# Altium schematic sheet Relay P4.SchDoc("Relay P4")
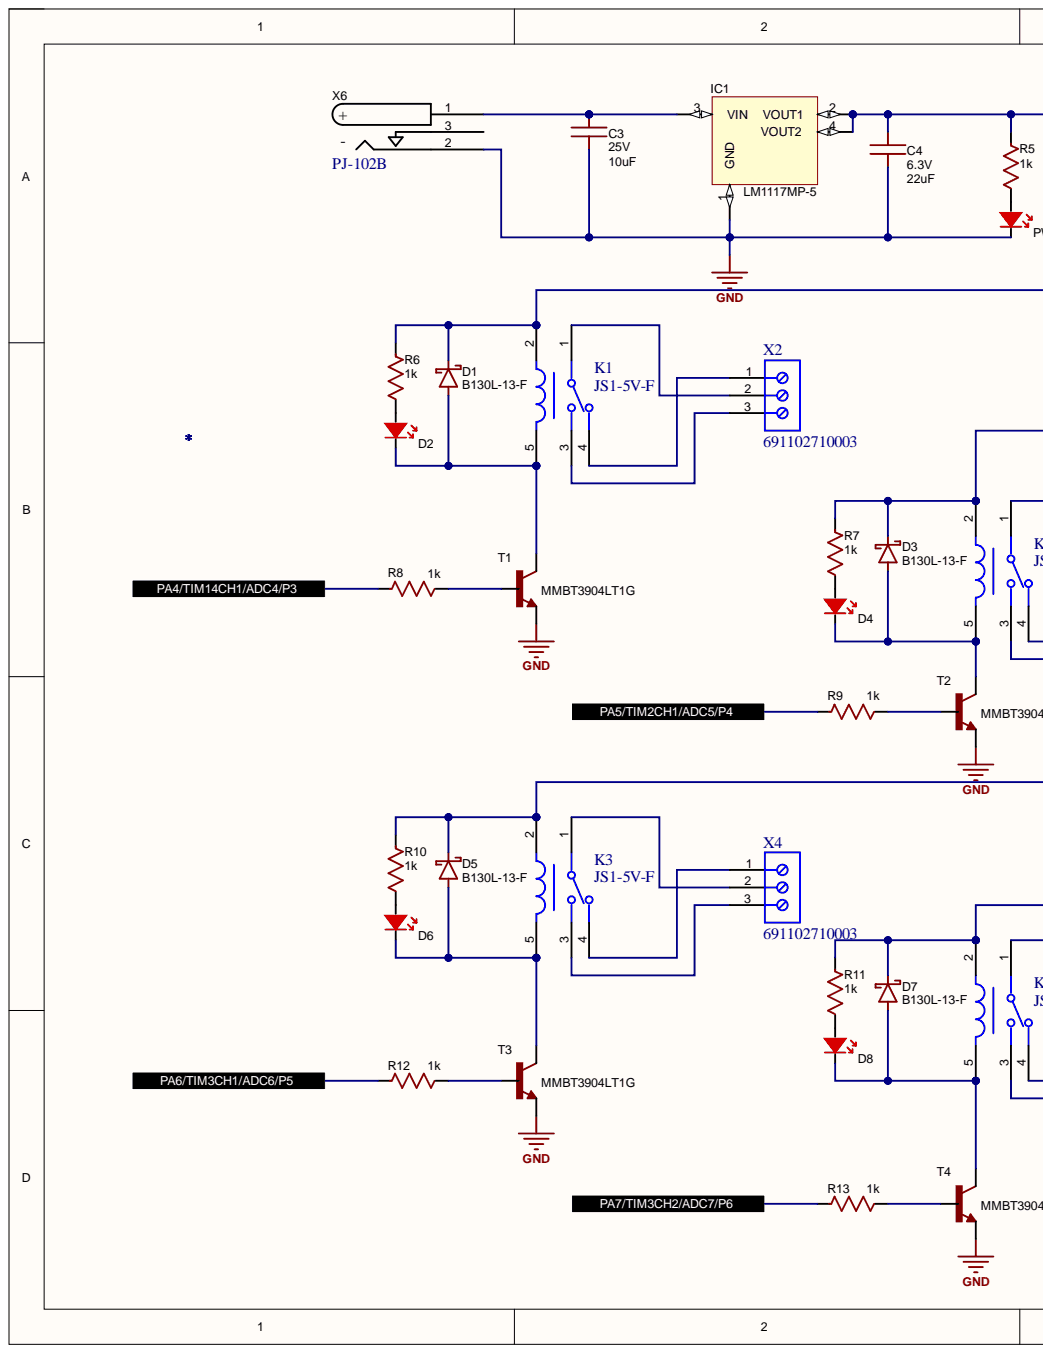
[diagram p0: Relay P4.SchDoc, left]
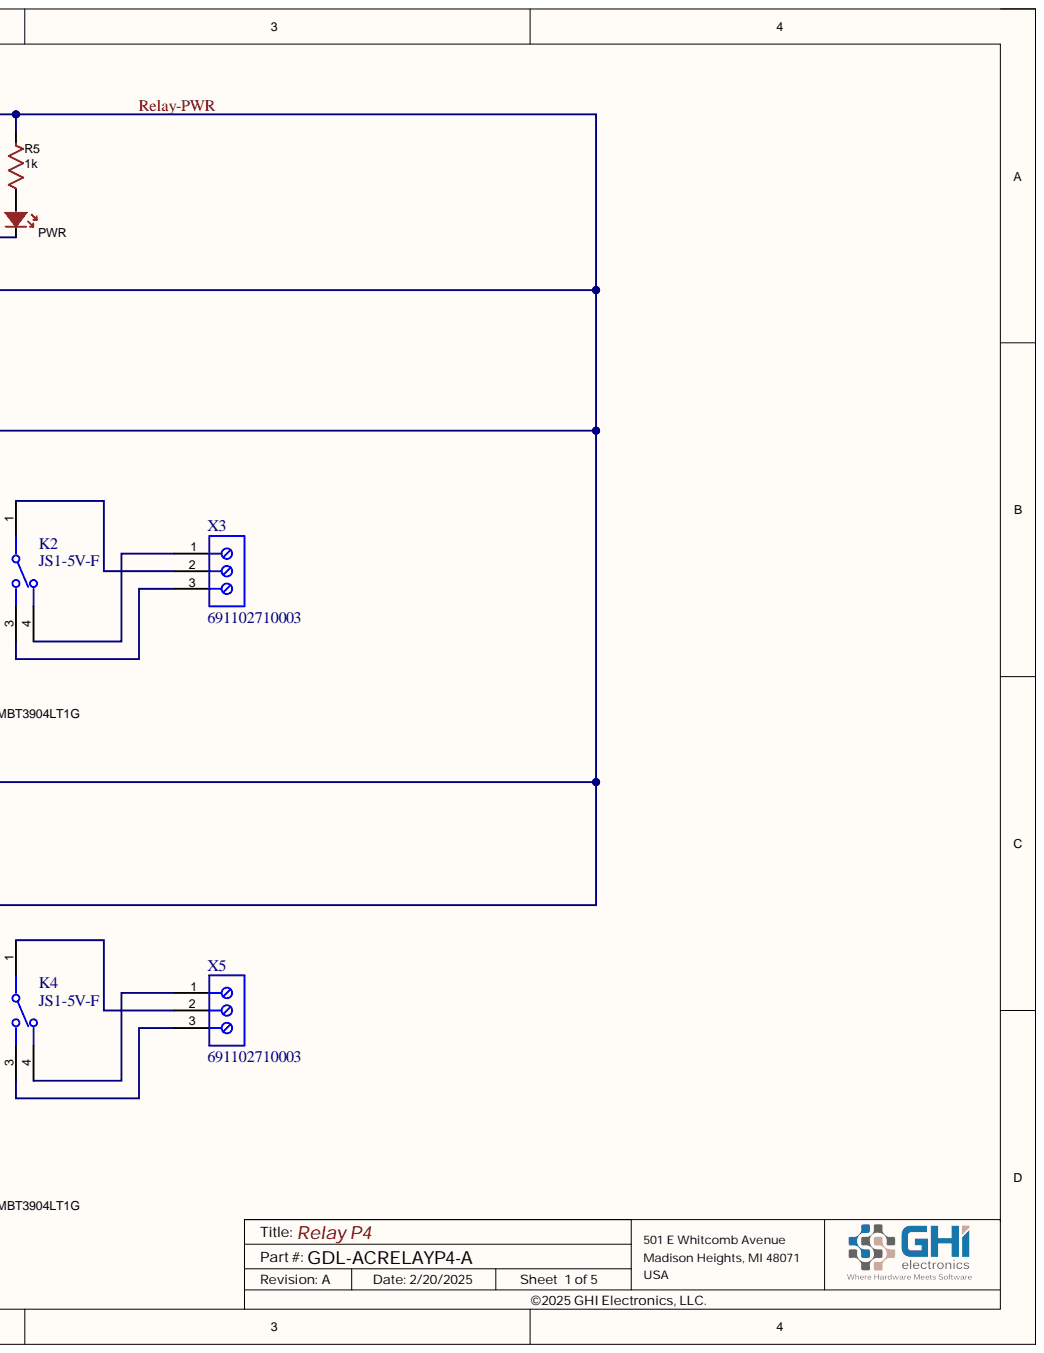
[diagram p1: Relay P4.SchDoc, right]

## Parts
C3  value 10uF  Capacitance: 10uF  Voltage: 25V  [C0805 L]  (hidden comment: 0805 Cap 10uF)
C4  value 22uF  Capacitance: 22uF  Voltage: 6.3V  [C0805 L]  (hidden comment: 0805 Cap 22uF)
D1  B130L-13-F  [SMA]
D2  0603 LED Red  [0603 LED Red]  (value not drawn)
D3  B130L-13-F  [SMA]
D4  0603 LED Red  [0603 LED Red]  (value not drawn)
D5  B130L-13-F  [SMA]
D6  0603 LED Red  [0603 LED Red]  (value not drawn)
D7  B130L-13-F  [SMA]
D8  0603 LED Red  [0603 LED Red]  (value not drawn)
IC1  value LM1117MP-5  [SOT223]
K1  JS1-5V-F  [FP-JS1-5V-F-MFG]
K2  JS1-5V-F  [FP-JS1-5V-F-MFG]
K3  JS1-5V-F  [FP-JS1-5V-F-MFG]
K4  JS1-5V-F  [FP-JS1-5V-F-MFG]
PWR  0603 LED Red  [0603 LED Red]  (value not drawn)
R5  1k  [R0402]
R6  1k  [R0402]
R7  1k  [R0402]
R8  1k  [R0402]
R9  1k  [R0402]
R10  1k  [R0402]
R11  1k  [R0402]
R12  1k  [R0402]
R13  1k  [R0402]
T1  3904  value MMBT3904LT1G  [SOT23]
T2  3904  value MMBT3904LT1G  [SOT23]
T3  3904  value MMBT3904LT1G  [SOT23]
T4  3904  value MMBT3904LT1G  [SOT23]
X2  691102710003  [691102710003]
X3  691102710003  [691102710003]
X4  691102710003  [691102710003]
X5  691102710003  [691102710003]
X6  PJ-102B  [PJ-102B Power Connector]

## Ports
PA4/TIM14CH1/ADC4/P3, PA5/TIM2CH1/ADC5/P4, PA6/TIM3CH1/ADC6/P5, PA7/TIM3CH2/ADC7/P6

## Nets
GND: C3.1 C4.1 IC1.1(GND) PWR.1 T1.2 T2.2 T3.2 T4.2 X6.2
PA4/TIM14CH1/ADC4/P3: R8.1 ; port PA4/TIM14CH1/ADC4/P3
PA5/TIM2CH1/ADC5/P4: R9.1 ; port PA5/TIM2CH1/ADC5/P4
PA6/TIM3CH1/ADC6/P5: R12.1 ; port PA6/TIM3CH1/ADC6/P5
PA7/TIM3CH2/ADC7/P6: R13.1 ; port PA7/TIM3CH2/ADC7/P6
Relay-PWR: C4.2 D1.K D3.K D5.K D7.K IC1.2(VOUT1) IC1.4(VOUT2) K1.2 K2.2 K3.2 K4.2 R5.1 R6.1 R7.1 R10.1 R11.1
NetC3_2: C3.2 IC1.3(VIN) X6.1
NetD1_A: D1.A D2.1 K1.5 T1.3
NetD2_2: D2.2 R6.2
NetD3_A: D3.A D4.1 K2.5 T2.3
NetD4_2: D4.2 R7.2
NetD5_A: D5.A D6.1 K3.5 T3.3
NetD6_2: D6.2 R10.2
NetD7_A: D7.A D8.1 K4.5 T4.3
NetD8_2: D8.2 R11.2
NetK1_1: K1.1 X2.2
NetK1_3: K1.3 X2.3
NetK1_4: K1.4 X2.1
NetK2_1: K2.1 X3.2
NetK2_3: K2.3 X3.3
NetK2_4: K2.4 X3.1
NetK3_1: K3.1 X4.2
NetK3_3: K3.3 X4.3
NetK3_4: K3.4 X4.1
NetK4_1: K4.1 X5.2
NetK4_3: K4.3 X5.3
NetK4_4: K4.4 X5.1
NetPWR_2: PWR.2 R5.2
NetR8_2: R8.2 T1.1
NetR9_2: R9.2 T2.1
NetR12_2: R12.2 T3.1
NetR13_2: R13.2 T4.1

Not connected: X6 3

## Library data of the parts
C3 = 0805 Cap 10uF: 10uF ±10% 25V X5R 0805 Multilayer Ceramic Capacitors MLCC - SMD/SMT RoHS; Manufacturer: YAGEO; Mfr.Part #: CC0805KKX5R8BB106; Category: Ceramic; Component Kind: Standard; LCSC Part #: C89831; Package: 0805; Tolerance: ±10%; Library Name: IM-Passives.SchLib
C4 = 0805 Cap 22uF: 22uF ±20% 6.3V X5R 0805 Multilayer Ceramic Capacitors MLCC - SMD/SMT RoHS; Mfr.Part #: CL21A226MQCLRNC; Category: Ceramic; Component Kind: Standard; LCSC Part #: C307530; Package: 0805; Tolerance: ±20%; Library Name: GHI-Passives.SchLib
D1 D3 D5 D7 = B130L-13-F 1A Schottky SMA: 30V 1A 410mV @ 1A SMA(DO-214AC) Schottky Barrier Diodes (SBD) RoHS; GHI Part Number: EPC-DB130L-13; Manufacturer: Diodes Incorporated; Component Kind: Standard; Component Type: Diode; LCSC Part #: C134425; Library Name: GHI.SchLib; Mfr.Part #: B130L-13-F; Package: SMA(DO-214AC); Value: B130L-13-F
D2 D4 D6 D8 PWR = 0603 LED Red: Red 631nm 0603 Light Emitting Diodes (LED) RoHS; Manufacturer: Lite-On; Mfr.Part #: LTST-C193KRKT-5A; Color: Red; Component Kind: Standard; LCSC Part #: C157741; Package: 0603; Value: 0603 LED Red; Library Name: GHI-Opto.SchLib
IC1 = LM1117MPX-5.0: IC REG LIN 5V 800MA SOT223-4; DeviceSetName: LM1117; GateName_1: G$1; Component Kind: Standard; SymbolName_1: LM1117; Library Name: GHI.SchLib; LibraryName: GHI
K1-K4 = CMP-05606-000016-1: RELAY GEN PURPOSE SPDT 10A 5V; Manufacturer: Panasonic; Coil Current: 72mA; Coil Power: 360mW; Coil Resistance: 69.4Ω; Coil Type: Non-Latching; Number Of Contacts: 1; Standoff Height: 0.3mm; Switching Power: 150W; Ambient Temperature Range High: +70°C; Ambient Temperature Range Low: -40°C; Automotive: No; Category: Relay; Coil Voltage: 5V; Component Kind: Standard; ComponentLink2Description: Datasheet; ComponentLink2URL: https://www3.panasonic.biz/ac/e_download/control/relay/power/catalog/mech_eng_js.pdf?via=ok; Device Class L1: Electromechanical; Device Class L2: Relays; Device Class L3: Power Relays; Digikey Description: RELAY GEN PURPOSE SPDT 10A 5V; Height: 16.8mm; Lead Free: Yes; Library Name: Altium Content Vault; Manufacturer Part Number: JS1-5V-F; Mouser Description: JS Series 10 A SPDT 5 V Through Hole Sealed Miniature Non-Polarized Power Relay; Octopart: https://octopart.com/js1-5v-f-panasonic-39428621; Package: JS1-5V-F; RoHS: TRUE; Switching Current: 10A; Temperature: -40°C to +70°C; Temperature Range High: +70°C; Temperature Range Low: -40°C; Throw Configuration: SPDT
R5-R13 = 0402 Res 0: ±5% 1/16W 0402 Chip Resistor - Surface Mount RoHS; Manufacturer: YAGEO; Voltage Rating: *V; Component Kind: Standard; Package: 0402; Power Rating: 1/16W; Resistance: 1k; Resistance Tolerance: ±5%; Value: 1k; Library Name: GHI-Passives.SchLib
T1-T4 = MMBT3904LT1G: NPN 200mA 40V 300mW SOT-23(SOT-23-3) Transistors (NPN/PNP) RoHS; Manufacturer: ON Semicon; Component Kind: Standard; LCSC Part #: C81464; Mfr.Part #: MMBT3904LT1G; Package: SOT-23(SOT-23-3); Library Name: GHI-Semiconductors.SchLib
X2-X5 = CMP-1502-03259-2: NexarPartId: 8917707; Current: 15A; Number of Contacts: 3; Number of Levels: 1; Pitch: 5.0038mm; Plating: Steel; Stripping Length: -6mm; Voltage: 300V; Wire Gauge (Max): 22AWG; Wire Gauge (Min): 14AWG; Color: Blue; Component Kind: Standard; Contact Material: Brass; Contact Plating: Tin; Current Rating: 15A; Height: 13.4mm; Housing Material: Nylon; Lead Pitch: 5mm; Length: 7.5mm; Library Name: Altium Content Vault; Max Operating Temperature: 105°C; Min Operating Temperature: -40°C; Mount: Through Hole; Number of Pins: 3; Number of Positions Per Level: 3; Packaging: Box; REACH SVHC: Unknown; RoHS: Compliant; Termination: Screw; Voltage Rating: 300V; Width: 16.2mm; Wire/Cable Gauge: 22AWG
X6 = PJ-102B: Component Kind: Standard; Library Name: GHI.SchLib
